# Altium schematic sheet SSRoof.SchDoc
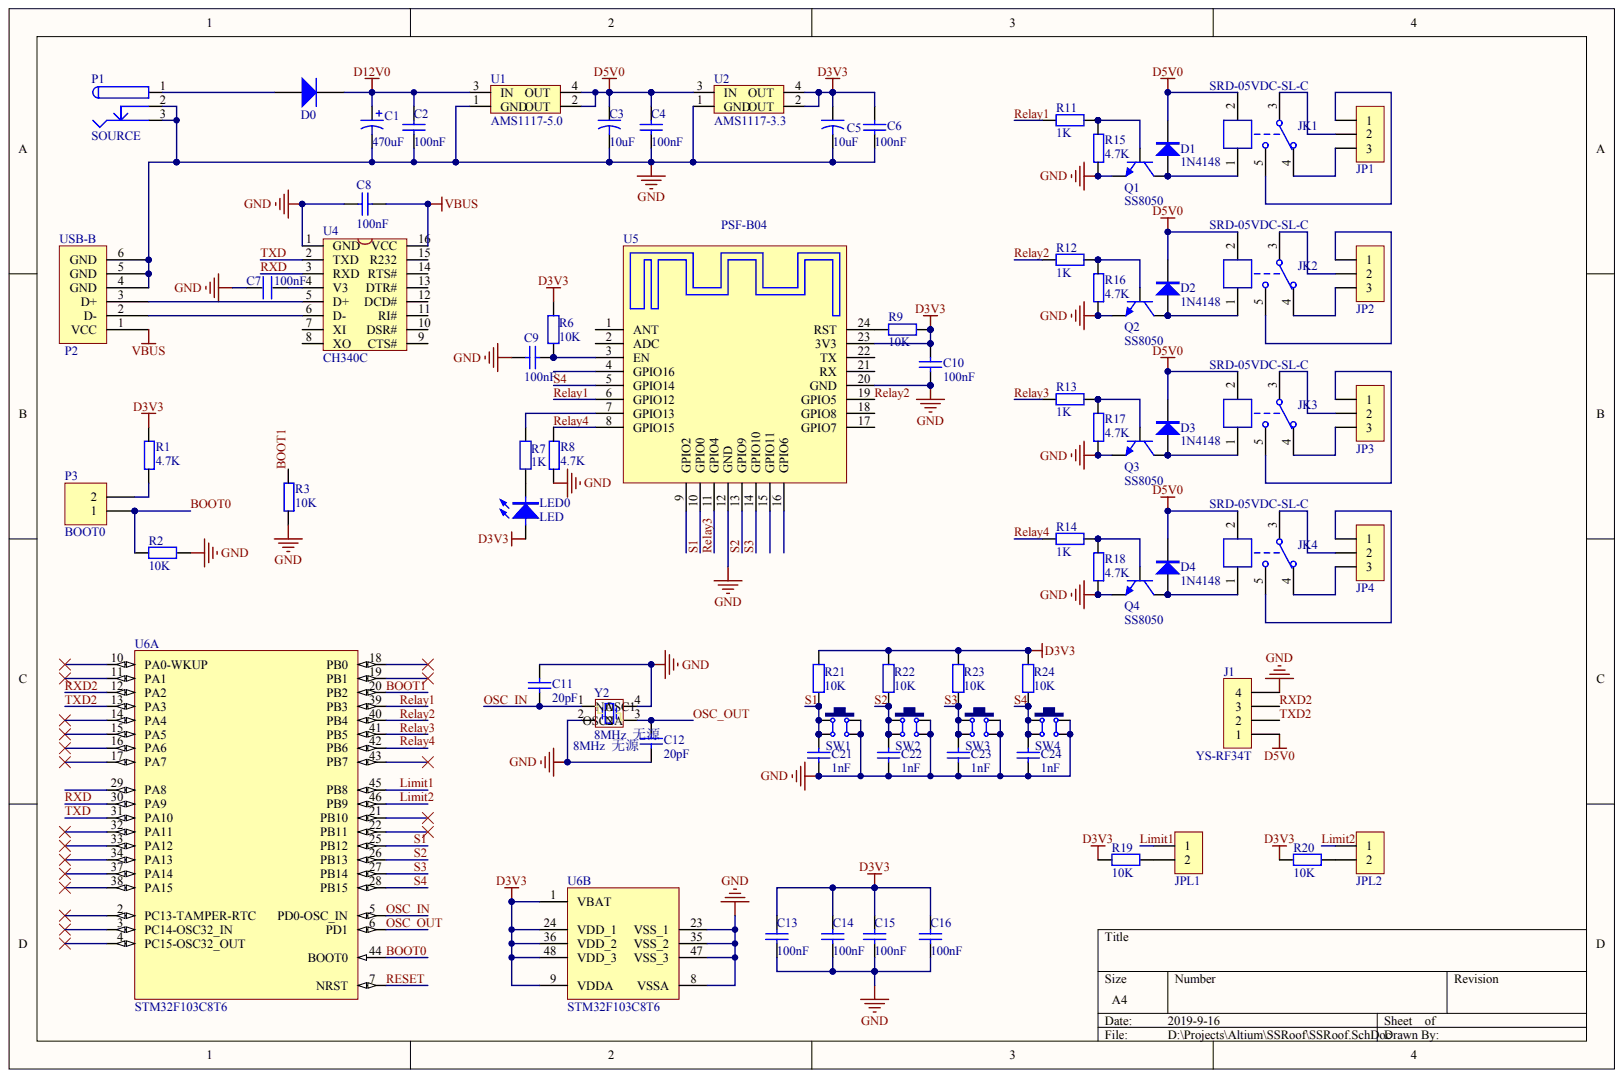
[diagram p0: SSRoof.SchDoc]

## Parts
C1  470uF
C2  100nF
C3  10uF
C4  100nF
C5  10uF
C6  100nF
C7  100nF
C8  100nF
C9  100nF
C10  100nF
C11  20pF
C12  20pF
C13  100nF
C14  100nF
C15  100nF
C16  100nF
C21  1nF
C22  1nF
C23  1nF
C24  1nF
D0  M7  (value not drawn)
D1  1N4148
D2  1N4148
D3  1N4148
D4  1N4148
J1  YS-RF34T
JK1  SRD-05VDC-SL-C
JK2  SRD-05VDC-SL-C
JK3  SRD-05VDC-SL-C
JK4  SRD-05VDC-SL-C
JP1  HDR-1X3  (value not drawn)
JP2  HDR-1X3  (value not drawn)
JP3  HDR-1X3  (value not drawn)
JP4  HDR-1X3  (value not drawn)
JPL1  HDR-1X2  (value not drawn)
JPL2  HDR-1X2  (value not drawn)
LED0  LED
P1  SOURCE
P2  USB-B
P3  BOOT0
Q1  SS8050
Q2  SS8050
Q3  SS8050
Q4  SS8050
R1  4.7K
R2  10K
R3  10K
R6  10K
R7  1K
R8  4.7K
R9  10K
R11  1K
R12  1K
R13  1K
R14  1K
R15  4.7K
R16  4.7K
R17  4.7K
R18  4.7K
R19  10K
R20  10K
R21  10K
R22  10K
R23  10K
R24  10K
SW1  6x6x5  (value not drawn)
SW2  6x6x5  (value not drawn)
SW3  6x6x5  (value not drawn)
SW4  6x6x5  (value not drawn)
U1  AMS1117-5.0
U2  AMS1117-3.3
U4  CH340C
U5  PSF-B04
U6  STM32F103C8T6  (parts A,B)
Y2  8MHz  无源

## Nets
D3V3: C5.1 C6.2 C10.1 C13.2 C14.2 C15.2 C16.2 LED0.1 R1.2 R6.2 R9.2 R19.1 R20.1 R21.2 R22.2 R23.2 R24.2 U2.2(OUT) U2.4(OUT) U5.23(3V3) U6.1(VBAT) U6.9(VDDA) U6.24(VDD_1) U6.36(VDD_2) U6.48(VDD_3)
D5V0: C3.1 C4.2 D1.2 D2.2 D3.2 D4.2 J1.1 JK1.2 JK2.2 JK3.2 JK4.2 U1.2(OUT) U1.4(OUT) U2.3(IN)
D12V0: C1.1 C2.2 D0.2 U1.3(IN)
GND: C1.2 C2.1 C3.2 C4.1 C5.2 C6.1 C7.1 C8.1 C9.2 C10.2 C11.2 C12.2 C13.1 C14.1 C15.1 C16.1 C21.2 C22.2 C23.2 C24.2 J1.4 P1.2 P1.3 P2.4(GND) P2.5(GND) P2.6(GND) Q1.2 Q2.2 Q3.2 Q4.2 R2.2 R3.1 R8.1 R15.1 R16.1 R17.1 R18.1 SW1.2 SW1.3 SW2.2 SW2.3 SW3.2 SW3.3 SW4.2 SW4.3 U1.1(GND) U2.1(GND) U4.1(GND) U5.12(GND) U5.20(GND) U6.8(VSSA) U6.23(VSS_1) U6.35(VSS_2) U6.47(VSS_3) Y2.2 Y2.4
VBUS: C8.2 P2.1(VCC) U4.16(VCC)
BOOT0: P3.1 R2.1 U6.44(BOOT0)
BOOT1: R3.2 U6.20(PB2)
LIMIT1: JPL1.1 U6.45(PB8)
LIMIT2: JPL2.1 U6.46(PB9)
OSC_IN: C11.1 U6.5(PD0-OSC_IN) Y2.1(NA)
OSC_OUT: C12.1 U6.6(PD1) Y2.3(NA)
RELAY1: R11.2 U5.6(GPIO12) U6.39(PB3)
RELAY2: R12.2 U5.19(GPIO5) U6.40(PB4)
RELAY3: R13.2 U5.11(GPIO4) U6.41(PB5)
RELAY4: R8.2 R14.2 U5.8(GPIO15) U6.42(PB6)
RESET: U6.7(NRST)
RXD: U4.3(RXD) U6.30(PA9)
RXD2: J1.3 U6.12(PA2)
S1: C21.1 R21.1 SW1.1 SW1.4 U5.10(GPIO0) U6.25(PB12)
S2: C22.1 R22.1 SW2.1 SW2.4 U5.13(GPIO9) U6.26(PB13)
S3: C23.1 R23.1 SW3.1 SW3.4 U5.14(GPIO10) U6.27(PB14)
S4: C24.1 R24.1 SW4.1 SW4.4 U5.5(GPIO14) U6.28(PB15)
TXD: U4.2(TXD) U6.31(PA10)
TXD2: J1.2 U6.13(PA3)
NetC7_2: C7.2 U4.4(V3)
NetC9_1: C9.1 R6.1 U5.3(EN)
NetD0_1: D0.1 P1.1
NetD1_1: D1.1 JK1.1 Q1.3
NetD2_1: D2.1 JK2.1 Q2.3
NetD3_1: D3.1 JK3.1 Q3.3
NetD4_1: D4.1 JK4.1 Q4.3
NetJK1_3: JK1.3 JP1.2
NetJK1_4: JK1.4 JP1.3
NetJK1_5: JK1.5 JP1.1
NetJK2_3: JK2.3 JP2.2
NetJK2_4: JK2.4 JP2.3
NetJK2_5: JK2.5 JP2.1
NetJK3_3: JK3.3 JP3.2
NetJK3_4: JK3.4 JP3.3
NetJK3_5: JK3.5 JP3.1
NetJK4_3: JK4.3 JP4.2
NetJK4_4: JK4.4 JP4.3
NetJK4_5: JK4.5 JP4.1
NetJPL1_2: JPL1.2 R19.2
NetJPL2_2: JPL2.2 R20.2
NetLED0_2: LED0.2 R7.2
NetP2_2: P2.2(D-) U4.6(D-)
NetP2_3: P2.3(D+) U4.5(D+)
NetP3_2: P3.2 R1.1
NetQ1_1: Q1.1 R11.1 R15.2
NetQ2_1: Q2.1 R12.1 R16.2
NetQ3_1: Q3.1 R13.1 R17.2
NetQ4_1: Q4.1 R14.1 R18.2
NetR7_1: R7.1 U5.7(GPIO13)
NetR9_1: R9.1 U5.24(RST)

Not connected: U4 7(XI) 8(XO) 9(CTS#) 10(DSR#) 11(RI#) 12(DCD#) 13(DTR#) 14(RTS#) 15(R232); U5 1(ANT) 2(ADC) 4(GPIO16) 9(GPIO2) 15(GPIO11) 16(GPIO6) 17(GPIO7) 18(GPIO8) 21(RX) 22(TX); U6 2(PC13-TAMPER-RTC) 3(PC14-OSC32_IN) 4(PC15-OSC32_OUT) 10(PA0-WKUP) 11(PA1) 14(PA4) 15(PA5) 16(PA6) 17(PA7) 18(PB0) 19(PB1) 21(PB10) 22(PB11) 29(PA8) 32(PA11) 33(PA12) 34(PA13) 37(PA14) 38(PA15) 43(PB7)

## Library data of the parts
D1-D4 = (no symbol name): BOM名称: 高速开关二极管; 版权归属: 源创客; 学习资源: www.ooocke.com
JK1-JK4 = (no symbol name): BOM名称: 5V继电器; 版权归属: 源创客; 学习资源: www.ooocke.com
JP1-JP4 = (no symbol name): BOM名称: 3P接插件; 版权归属: 源创客; 学习资源: www.ooocke.com
JPL1 JPL2 = (no symbol name): BOM名称: 2P接插件; 版权归属: 源创客; 学习资源: www.ooocke.com
Q1-Q4 = (no symbol name): BOM名称: 高频放大-NPN型; 版权归属: 源创客; 属性: 40V 1.5A 1W 100MHZ; 学习资源: www.ooocke.com
R2 R6 R9 R19-R24 = (no symbol name): Value: 1K; Published: 8-Jun-2000; Revision: July-2002: Re-released for DXP Platform.; Publisher: Altium Limited
SW1-SW4 = (no symbol name): BOM名称: 贴片6x6轻触开关; 版权归属: 源创客; 学习资源: www.ooocke.com
C1-C16 C21-C24 D0 J1 LED0 P1-P3 R1 R3 R7 R8 R11-R18 U1 U2 U4-U6 Y2 = (no symbol name)
  C2 C4 C6 C8-C10 C13-C16: Published: 8-Jun-2000; LatestRevisionDate: 17-Jul-2002; LatestRevisionNote: Re-released for DXP Platform.; PackageReference: RAD-0.3; Value: 100nF
  C3 C5: vaule: 10uF
  C11 C12: Published: 8-Jun-2000; LatestRevisionDate: 17-Jul-2002; LatestRevisionNote: Re-released for DXP Platform.; PackageReference: RAD-0.3; Value: 20pF
  C21-C24: Published: 8-Jun-2000; LatestRevisionDate: 17-Jul-2002; LatestRevisionNote: Re-released for DXP Platform.; PackageReference: RAD-0.3; Value: 1nF
  D0: Published: 8-Jun-2000; LatestRevisionDate: 17-Jul-2002; LatestRevisionNote: Re-released for DXP Platform.; DatasheetDocument: 1997; PackageReference: DO-35; Code_JEDEC: DO-204-AH; ComponentLink1Description: Manufacturer Link; ComponentLink1URL: http://www.fairchildsemi.com/; ComponentLink2Description: Datasheet; ComponentLink2URL: http://www.fairchildsemi.com/ds/1N%2F1N4448.pdf
  P1 P3: LatestRevisionDate: 17-Jul-2002; LatestRevisionNote: Re-released for DXP Platform.
  R7 R11-R14: Published: 8-Jun-2000; Revision: July-2002: Re-released for DXP Platform.; Value: 1K
  U6: DatasheetVersion: rev13.0, Apr-2011; PackageVersion: rev13, Apr-2011; PackageReference: LQFP48; PackageDescription: 48-Pin Low-Profile Quad Flat Pack, 7 x 7 mm Body, 0.5 mm Pitch (LQFP48); ComponentLink1Description: Manufacturer URL; ComponentLink1URL: http://www.st.com/; ComponentLink2Description: STM32F103 Datasheet; ComponentLink2URL: http://www.st.com/internet/com/TECHNICAL_RESOURCES/TECHNICAL_LITERATURE/DATASHEET/CD00161566.pdf; ComponentLink3Description: STM32 Family Web Page; ComponentLink3URL: www.st.com/stm32
  Y2: Published: 8-Jun-2000; LatestRevisionDate: 17-Jul-2002; LatestRevisionNote: Re-released for DXP Platform.; PackageReference: R38; Value: 8MHz  无源
  C2 C4 C6 C8-C16 C21-C24 D0 P1 P3 R7 R11-R14 Y2: Publisher: Altium Limited
